# Altium schematic sheet Battery_Charging.SchDoc
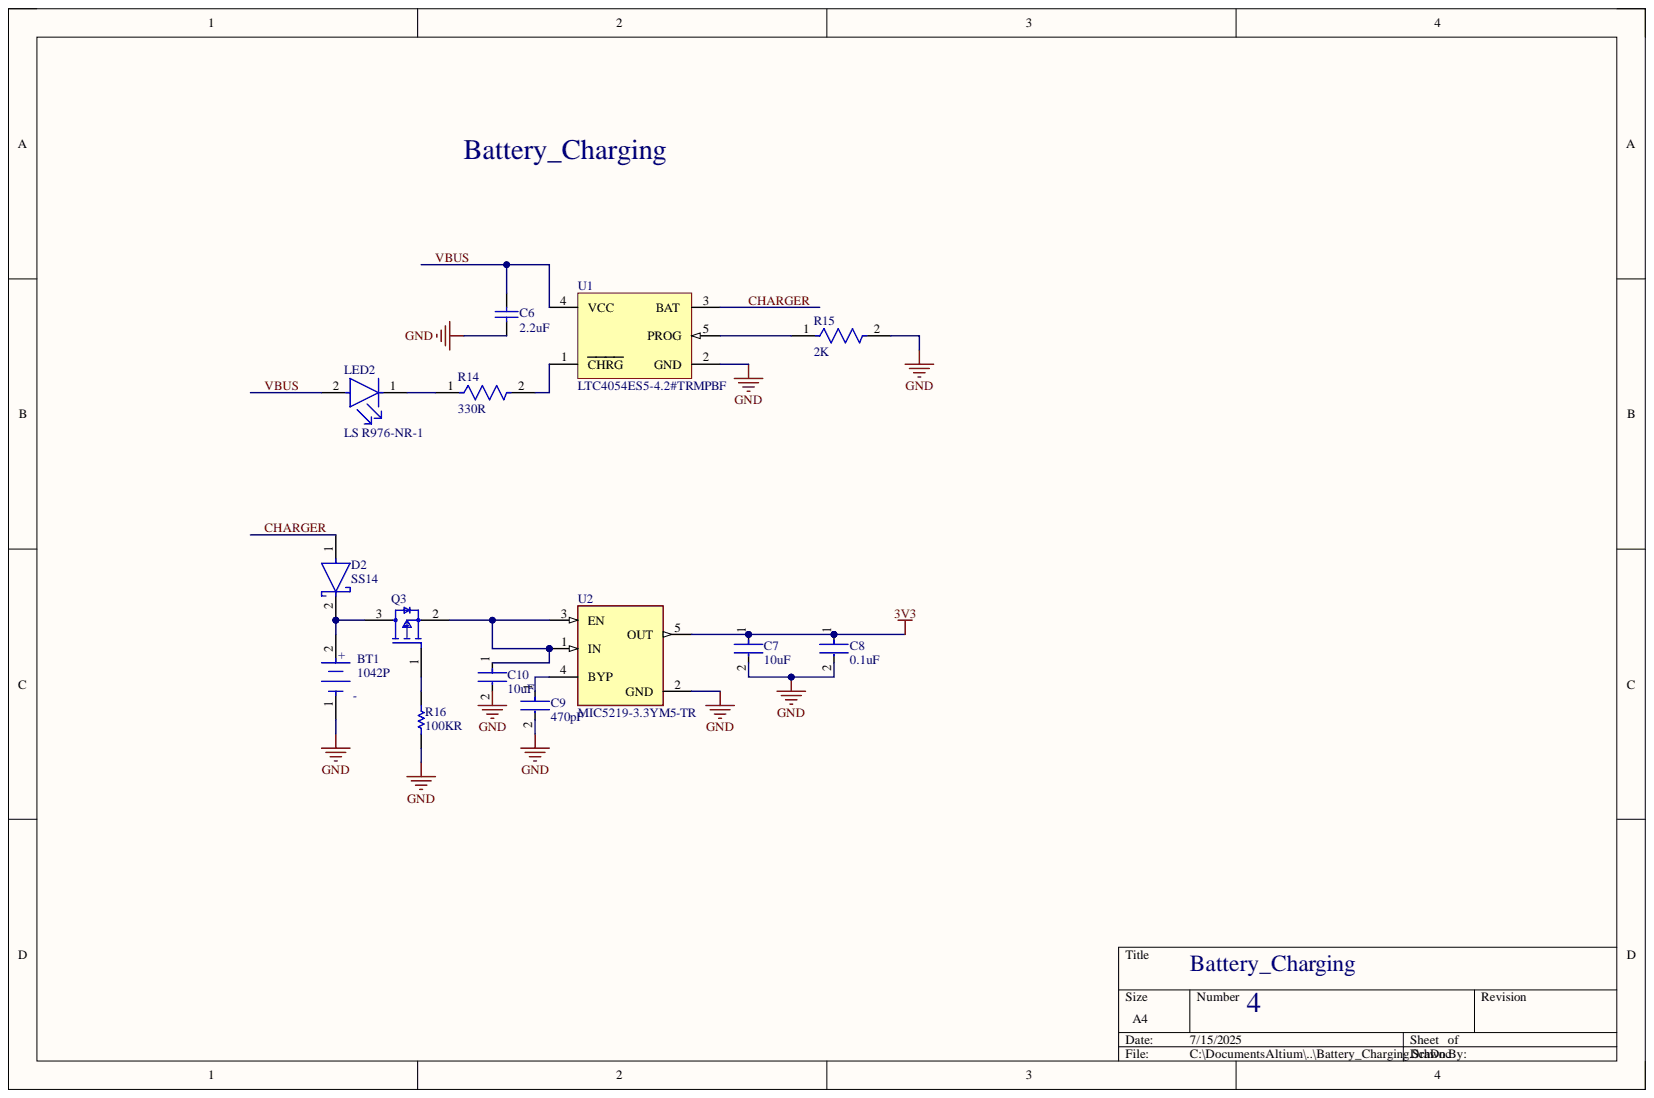
[diagram p0: Battery_Charging.SchDoc]

## Parts
BT1  1042P  [FP-1042P-MFG]
C6  2.2uF  [CAPC2013X145X50ML20T25]
C7  10uF  [FP-CL21-IPC_C]
C8  0.1uF  [FP-CC0805-EA-MFG]
C9  470pF  [FP-C0603C-CF-MFG]
C10  10uF  [FP-CL21-IPC_A]
D2  SS14  [FP-403AE-MFG]
LED2  LS R976-NR-1  [FP-LS_R976-MFG]
Q3  SI2301BDS-T1-GE3  [FP-S-03946-MFG]  (value not drawn)
R14  330R  [FP-CPF0603-MFG]
R15  2K  [FP-RMCF0603-IPC_A]
R16  100KR  [RESC2013X70X40ML20T20]
U1  LTC4054ES5-4.2#TRMPBF  [LT-S5-5-TSOT-23_M]
U2  MIC5219-3.3YM5-TR  [FP-SOT23-5LD-PL-1-IPC_C]

## Nets
3V3: C7.1 C8.1 U2.5(OUT)
GND: BT1.1 C6.1 C7.2 C8.2 C9.2 C10.2 R15.2 R16.2 U1.2(GND) U2.2(GND)
CHARGER: D2.1 U1.3(BAT)
VBUS: C6.2 LED2.2 U1.4(VCC)
NetBT1_2: BT1.2 D2.2 Q3.3
NetC9_1: C9.1 U2.4(BYP)
NetC10_1: C10.1 Q3.2 U2.1(IN) U2.3(EN)
NetLED2_1: LED2.1 R14.1
NetQ3_1: Q3.1 R16.1
NetR14_2: R14.2 U1.1(CHRG)
NetR15_1: R15.1 U1.5(PROG)

## Library data of the parts
BT1 = CMP-19636-000019-1: BATTERY HOLDER 18650 SMD TAB; Number of Cells: 1; Component Kind: Standard; Lead Free: Lead Free; Library Name: Altium Content Vault; Max Operating Temperature: 145°C; Min Operating Temperature: -50°C; Mount: Surface Mount; Package Quantity: 200; Packaging: Tray; Termination: SMD/SMT
C6 = CMP-1036-04819-2: Manufacturer: Kemet; Part Number: C0805C225K9PACTU; Component Kind: Standard; Library Name: Altium Content Vault
C7 = CMP-13271-000175-1: CL21 Series 0805 10uF 6.3V ±10% Tolerance X6S Multilayer Ceramic Chip Capacitor; Capacitance: 10uF; Case Code (Imperial): 0805; Case Code (Metric): 2012; Case/Package: 0805; Component Kind: Standard; Depth: 1.25mm; Dielectric: X6S; Height: 1.25mm; Lead Free: Lead Free; Length: 2mm; Library Name: Altium Content Vault; Max Operating Temperature: 105°C; Min Operating Temperature: -55°C; Mount: Surface Mount; Package Quantity: 1; Packaging: Tape and Reel; Radiation Hardening: No; Thickness: 0.055inch; Tolerance: 10%; Voltage Rating: 6.3V; Voltage Rating (DC): 6.3V; Width: 0.049inch
C8 = CMP-03422-000810-2: CAP CER X7R 0805; Component Kind: Standard; Library Name: Altium Content Vault; RoHS Compliant: Yes
C9 = CMP-03020-003327-1: CAP CER 470PF 10V X7R 0603; Manufacturer: KEMET; Manufacturer Part Number: C0603C471K8RACTU; Automotive Grade: Grade 1; Material: Ceramic; Temperature Coefficient: 30ppm/°C; Tolerance: 0.1; Automotive: Yes; Capacitance: 470 pF; Category: Cap; Component Kind: Standard; ComponentLink2Description: Datasheet; ComponentLink2URL: https://api.kemet.com/component-edge/download/datasheet/C0603C100J5GACAUTO.pdf; Device Class L1: Passive Components; Device Class L2: Capacitors; Device Class L3: Ceramic Capacitors; Digikey Description: CAP CER 470PF 10V X7R 0603; Height: 0.87mm; Lead Free: Yes; Library Name: Altium Content Vault; Mouser Description: Capacitor, Ceramic, 470Pf, 10Vdc, 10-% Tol, 10+% Tol, X7r-Tc Code, -15, 15%-Tc Rohs Compliant: Yes; Octopart: https://octopart.com/c0603c471k8ractu-kemet-9138956; Package: C0603C-CF; RoHS: TRUE; Temperature: -55°C to +125°C; Temperature Characteristic: X7R; Temperature Range High: +125°C; Temperature Range Low: -55°C; Value: 470 pF; Voltage Rating: 10 V
C10 = CMP-13271-001826-1: CL21 Series 0805 10uF 6.3V ±10% Tolerance X5R Multilayer Ceramic Chip Capacitor; Capacitance: 10uF; Case Code (Imperial): 0805; Case Code (Metric): 2012; Case/Package: 0805; Component Kind: Standard; Contact Plating: Tin; Depth: 1.25mm; Dielectric: X5R; Height: 1.25mm; Lead Free: Lead Free; Length: 2mm; Library Name: Altium Content Vault; Max Operating Temperature: 85°C; Min Operating Temperature: -55°C; Mount: Surface Mount; Package Quantity: 1; Packaging: Tape and Reel; Radiation Hardening: No; Thickness: 0.053inch; Tolerance: 10%; Voltage Rating: 6.3V; Voltage Rating (DC): 6.3V; Width: 0.049inch
D2 = CMP-2000-04943-2: DIODE SCHOTTKY 40V 1A SMA; Manufacturer: ON Semiconductor; Max Junction Temp: +125°C; Max Surge Forward Current: 40A; Peak Reverse Current: 0.2mA; Standoff Height: 0.05mm; Automotive: No; Category: Diode; Component Kind: Standard; ComponentLink2Description: Datasheet; ComponentLink2URL: https://www.onsemi.com/pub/Collateral/SS19-D.PDF; Device Class L1: Discrete Semiconductors; Device Class L2: Diodes; Device Class L3: Schottky Diodes; Digikey Description: DIODE SCHOTTKY 40V 1A SMA; Forward Current: 1A; Height: 2.5mm; Lead Free: Yes; Library Name: Altium Content Vault; Manufacturer Part Number: SS14; Max Repetitive Reverse Voltage: 40V; Mouser Description: Schottky Diodes & Rectifiers SMA 40V 1.0A; Octopart: https://octopart.com/ss14-on+semiconductor-29205033; Package: 403AE; RoHS: TRUE; Temperature: -65°C to +125°C; Temperature Range High: +125°C; Temperature Range Low: -65°C
LED2 = CMP-62016-000037-1: LED RED DIFFUSED 0805 SMD; Dominant Wavelength: 633nm; Forward Current: 25mA; Forward Voltage: 2.5V; Lens Color: White; Lens Size: 1.25mm; Lens Style: Flat; Luminous Flux: 0.47lm; Luminous Intensity: 180mcd; Number of Elements: 1; Power Dissipation: 65W; Reverse Voltage: 12V; Test Current: 20mA; Viewing Angle: 160°; Case Code (Imperial): 0805; Case Code (Metric): 2012; Case/Package: 0805; Color: Red; Component Kind: Standard; Depth: 1.35mm; Height: 0.9mm; Illumination Color: Red; Length: 2mm; Lens Transparency: Diffused; Library Name: Altium Content Vault; Max Operating Temperature: 85°C; Min Operating Temperature: -30°C; Mount: Surface Mount; Number of LEDs: 1; Number of Pins: 2; Package Quantity: 16000; Packaging: Tape and Reel; Radiation Hardening: No; Width: 1.25mm
Q3 = CMP-00030-42864886-1: SI2301BDS-T1-GE3 Trans Mosfet P-ch Si 20V 2.2A 3-PIN SOT-23 T/r / Mosfet P-ch 20V 2.2A SOT23-3; Continuous Drain Current (ID): -2.2A; Drain to Source Breakdown Voltage: -20V; Drain to Source Resistance: 100mΩ; Element Configuration: Single; Fall Time: 40ns; Gate to Source Voltage (Vgs): 8V; Input Capacitance: 375pF; Max Power Dissipation: 700mW; Nominal Vgs: -950mV; Number of Channels: 1; Turn-Off Delay Time: 30ns; Turn-On Delay Time: 20ns; Case/Package: SOT-23-3; Component Kind: Standard; Drain to Source Voltage (Vdss): -20V; Height: 1.02mm; Lead Free: Lead Free; Length: 3.04mm; Library Name: Altium Content Vault; Max Operating Temperature: 150°C; Min Operating Temperature: -55°C; Mount: Surface Mount; NexarPartId: 42864886; Number of Elements: 1; Number of Pins: 3; Power Dissipation: 700mW; Radiation Hardening: No; Rds On Max: 100mΩ; REACH SVHC: Unknown; Resistance: 100MΩ; Rise Time: 40ns; RoHS: Compliant; Schedule B: 8541210080, 8541210080; Threshold Voltage: -950mV; Weight: 1.437803g; Width: 1.4mm
R14 = CMP-03211-000699-1: RES SMD 330Ω 0.1% 1/16W 0603; Manufacturer: TE Connectivity; Manufacturer Part Number: CPF0603B330RE1; Power Rating: 0.063W; Temperature Coefficient: 25ppm/°C; Voltage Rating: 50V; Automotive: No; Category: Res; Component Kind: Standard; ComponentLink2Description: Datasheet; ComponentLink2URL: https://www.te.com/commerce/DocumentDelivery/DDEController?Action=showdoc&DocId=Data+Sheet%7F1773200%7FM%7Fpdf%7FEnglish%7FENG_DS_1773200_M.pdf%7F3-1676481-2; Composition: Thin Film; Device Class L1: Passive Components; Device Class L2: Resistors; Device Class L3: Chip SMD Resistors; Height: 0.55mm; Ipc Land Pattern Name: RESC15580X45; Lead Free: Yes; Library Name: Altium Content Vault; Octopart: https://octopart.com/cpf0603b330re1-te+connectivity-9539413; octopart_url: https://octopart.com/cpf0603b330re1-te+connectivity-9539413; Package: CPF0603; packaging: 1k Reel; Resistance: 330Ω; RoHS: TRUE; Series: CPF; Temperature: -55°C to +155°C; Temperature Range High: +155°C; Temperature Range Low: -55°C; Tolerance: 0.1%; Value: 330Ω
R15 = CMP-26527-006357-1: 2kΩ ±1% 0.1W 0603 Thick Film Chip Resistor AEC-Q200 compliant; Max Power Dissipation: 100mW; Resistance: 2kR; Temperature Coefficient: 100ppm/°C; Working Voltage: 75V; Case Code (Imperial): 0603; Case Code (Metric): 1608; Case/Package: 0603; Component Kind: Standard; Construction: Rectangular; Contact Plating: Tin; Depth: 0.8mm; Features: Automotive AEC-Q200; Height: 0.022inch; Lead Free: Lead Free; Length: 1.55mm; Library Name: Altium Content Vault; Max Operating Temperature: 155°C; Military Standard: Not; Min Operating Temperature: -55°C; Mount: Surface Mount; Number of Terminations: 2; Packaging: Tape and Reel; Power Rating: 100mW; Radiation Hardening: No; Resistor Type: Thick Film; RoHS Compliant: Yes; Series: RMCF; Technology: THICK FILM; Tolerance: 1%; Width: 0.031inch
R16 = CMP-2001-04377-1: Manufacturer: Panasonic; Part Number: ERJ-6ENF1003V; Component Kind: Standard; Library Name: Altium Content Vault
U1 = CMP-0471-00454-1: Standalone Linear Li-Ion Battery Charger with Thermal Regulation, 4.25 to 6.5 V Vin, 5-pin SOT23 (S5-5), -40 to 85 degC, Pb-Free, Mini Tape and Reel; Manufacturer: Linear Technology; Battery Chemistry: Li-Ion, Li-Polymer; Charge Termination: C/10; Float Voltage: 4.2 V; Max Charge Current(A): 0.8; Number of Cells: 1; Vin Max(V): 6.5; Vin Min(V): 4.25; Code_JEDEC: MO-193; Component Kind: Standard; ComponentLink1Description: Manufacturer URL; ComponentLink1URL: http://www.linear.com/; ComponentLink2Description: Datasheet; ComponentLink2URL: http://cds.linear.com/docs/en/datasheet/405442xf.pdf; ComponentLink3Description: Package Specification; ComponentLink3URL: http://cds.linear.com/docs/en/packaging/SOT_5_05-08-1635.pdf; Library Name: Altium Content Vault; Mounting Technology: Surface Mount; PackageDescription: 5-Pin Plastic TSOT-23, Body 1.63 x 2.90 mm, Pitch 0.95 mm; PackageReference: S5-5; PackageVersion: Jun-10; Packing: Mini Tape and Reel; PartNumber: LTC4054ES5-4.2#TRMPBF; RoHS: TRUE; Type of Charger: Linear
U2 = CMP-06324-000027-1: IC REG LINEAR 3.3V 500MA SOT23-5; Dropout Voltage: 350mV; Max Input Voltage: 12V; Min Input Voltage: 2.5V; Number of Regulators: 1; Output Type: Fixed; Case/Package: SOT-23-5; Component Kind: Standard; Lead Free: Lead Free; Library Name: Altium Content Vault; Max Operating Temperature: 125°C; Min Operating Temperature: -40°C; Mount: Surface Mount; Nominal Output Voltage: 3.3V; Number of Pins: 5; Output Current: 500mA; Output Voltage: 3.3V; Packaging: Cut Tape; REACH SVHC: No SVHC
